# Altium schematic sheet Motor_Drive_V3.02.SchDoc(Motor_Drive_V3.02)
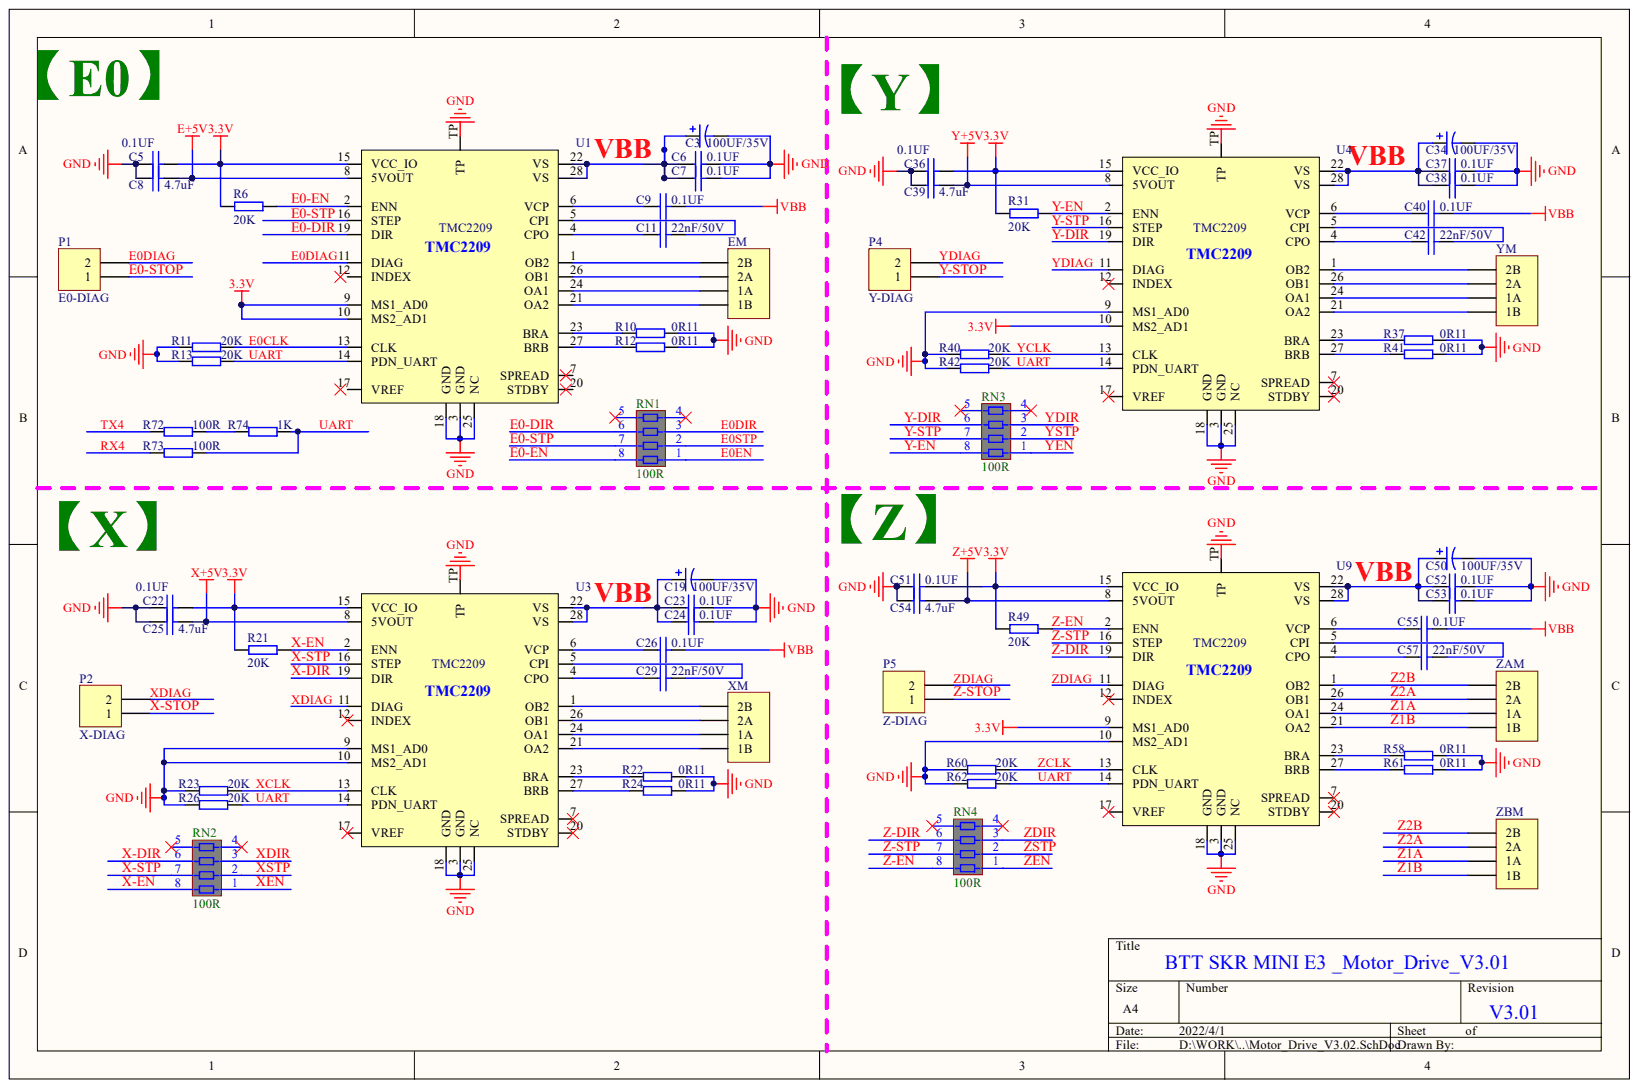
[diagram p0: Motor_Drive_V3.02.SchDoc]

## Parts
C3  value 100UF/35V  [100uF/35V-铝电解电容]  (hidden comment: 35V/100UF)
C5  0.1UF  [0603-C]
C6  0.1UF  [0603-C]
C7  0.1UF  [0603-C]
C8  4.7uF  [0603-C]
C9  0.1UF  [0603-C]
C11  22nF/50V  [0603-C]
C19  value 100UF/35V  [100uF/35V-铝电解电容]  (hidden comment: 35V/100UF)
C22  0.1UF  [0603-C]
C23  0.1UF  [0603-C]
C24  0.1UF  [0603-C]
C25  4.7uF  [0603-C]
C26  0.1UF  [0603-C]
C29  22nF/50V  [0603-C]
C34  value 100UF/35V  [100uF/35V-铝电解电容]  (hidden comment: 35V/100UF)
C36  0.1UF  [0603-C]
C37  0.1UF  [0603-C]
C38  0.1UF  [0603-C]
C39  4.7uF  [0603-C]
C40  0.1UF  [0603-C]
C42  22nF/50V  [0603-C]
C50  value 100UF/35V  [100uF/35V-铝电解电容]  (hidden comment: 35V/100UF)
C51  0.1UF  [0603-C]
C52  0.1UF  [0603-C]
C53  0.1UF  [0603-C]
C54  4.7uF  [0603-C]
C55  0.1UF  [0603-C]
C57  22nF/50V  [0603-C]
EM  XMO  [2.54-4P-直针插座]  (value not drawn)
P1  E0-DIAG  [SIP2-2.54-BLACK]
P2  X-DIAG  [SIP2-2.54-BLACK]
P4  Y-DIAG  [SIP2-2.54-BLACK]
P5  Z-DIAG  [SIP2-2.54-BLACK]
R6  20K  [0603-R]
R10  0R11  [0805R]
R11  20K  [0603-R]
R12  0R11  [0805R]
R13  20K  [0603-R]
R21  20K  [0603-R]
R22  0R11  [0805R]
R23  20K  [0603-R]
R24  0R11  [0805R]
R26  20K  [0603-R]
R31  20K  [0603-R]
R37  0R11  [0805R]
R40  20K  [0603-R]
R41  0R11  [0805R]
R42  20K  [0603-R]
R49  20K  [0603-R]
R58  0R11  [0805R]
R60  20K  [0603-R]
R61  0R11  [0805R]
R62  20K  [0603-R]
R72  100R  [0603-R]
R73  100R  [0603-R]
R74  1K  [0603-R]
RN1  100R  [0603*4]
RN2  100R  [0603*4]
RN3  100R  [0603*4]
RN4  100R  [0603*4]
U1  TMC2209  [QFN28-PAD]
U3  TMC2209  [QFN28-PAD]
U4  TMC2209  [QFN28-PAD]
U9  TMC2209  [QFN28-PAD]
XM  XMO  [2.54-4P-直针插座]  (value not drawn)
YM  XMO  [2.54-4P-直针插座]  (value not drawn)
ZAM  XMO  [2.54-4P-直针插座]  (value not drawn)
ZBM  XMO  [2.54-4P-直针插座]  (value not drawn)

## Nets
3.3V: C5.1 C22.1 C36.1 C51.1 R6.1 R21.1 R31.1 R49.1 U1.9(MS1_AD0) U1.10(MS2_AD1) U1.15(VCC_IO) U3.15(VCC_IO) U4.10(MS2_AD1) U4.15(VCC_IO) U9.9(MS1_AD0) U9.15(VCC_IO)
E+5V: C8.1 U1.8(5VOUT)
GND: C3.2 C5.2 C6.1 C7.1 C8.2 C19.2 C22.2 C23.1 C24.1 C25.2 C34.2 C36.2 C37.1 C38.1 C39.2 C50.2 C51.2 C52.1 C53.1 C54.2 R10.2 R11.1 R12.2 R13.1 R22.2 R23.1 R24.2 R26.1 R37.2 R40.1 R41.2 R42.1 R58.2 R60.1 R61.2 R62.1 U1.3(GND) U1.18(GND) U1.25(NC) U1.TP U3.3(GND) U3.9(MS1_AD0) U3.10(MS2_AD1) U3.18(GND) U3.25(NC) U3.TP U4.3(GND) U4.9(MS1_AD0) U4.18(GND) U4.25(NC) U4.TP U9.3(GND) U9.10(MS2_AD1) U9.18(GND) U9.25(NC) U9.TP
X+5V: C25.1 U3.8(5VOUT)
Y+5V: C39.1 U4.8(5VOUT)
Z+5V: C54.1 U9.8(5VOUT)
E0-DIR: RN1.6 U1.19(DIR)
E0-EN: R6.2 RN1.8 U1.2(ENN)
E0-STOP: P1.1
E0-STP: RN1.7 U1.16(STEP)
E0CLK: R11.2 U1.13(CLK)
E0DIAG: P1.2 U1.11(DIAG)
E0DIR: RN1.3
E0EN: RN1.1
E0STP: RN1.2
RX4: R73.1
TX4: R72.1
UART: R13.2 R26.2 R42.2 R62.2 R73.2 R74.2 U1.14(PDN_UART) U3.14(PDN_UART) U4.14(PDN_UART) U9.14(PDN_UART)
VBB: C3.1 C6.2 C7.2 C9.1 C19.1 C23.2 C24.2 C26.1 C34.1 C37.2 C38.2 C40.1 C50.1 C52.2 C53.2 C55.1 U1.22(VS) U1.28(VS) U3.22(VS) U3.28(VS) U4.22(VS) U4.28(VS) U9.22(VS) U9.28(VS)
X-DIR: RN2.6 U3.19(DIR)
X-EN: R21.2 RN2.8 U3.2(ENN)
X-STOP: P2.1
X-STP: RN2.7 U3.16(STEP)
XCLK: R23.2 U3.13(CLK)
XDIAG: P2.2 U3.11(DIAG)
XDIR: RN2.3
XEN: RN2.1
XSTP: RN2.2
Y-DIR: RN3.6 U4.19(DIR)
Y-EN: R31.2 RN3.8 U4.2(ENN)
Y-STOP: P4.1
Y-STP: RN3.7 U4.16(STEP)
YCLK: R40.2 U4.13(CLK)
YDIAG: P4.2 U4.11(DIAG)
YDIR: RN3.3
YEN: RN3.1
YSTP: RN3.2
Z1A: U9.24(OA1) ZAM.3(1A) ZBM.3(1A)
Z1B: U9.21(OA2) ZAM.4(1B) ZBM.4(1B)
Z2A: U9.26(OB1) ZAM.2(2A) ZBM.2(2A)
Z2B: U9.1(OB2) ZAM.1(2B) ZBM.1(2B)
Z-DIR: RN4.6 U9.19(DIR)
Z-EN: R49.2 RN4.8 U9.2(ENN)
Z-STOP: P5.1
Z-STP: RN4.7 U9.16(STEP)
ZCLK: R60.2 U9.13(CLK)
ZDIAG: P5.2 U9.11(DIAG)
ZDIR: RN4.3
ZEN: RN4.1
ZSTP: RN4.2
NetC9_2: C9.2 U1.6(VCP)
NetC11_1: C11.1 U1.5(CPI)
NetC11_2: C11.2 U1.4(CPO)
NetC26_2: C26.2 U3.6(VCP)
NetC29_1: C29.1 U3.5(CPI)
NetC29_2: C29.2 U3.4(CPO)
NetC40_2: C40.2 U4.6(VCP)
NetC42_1: C42.1 U4.5(CPI)
NetC42_2: C42.2 U4.4(CPO)
NetC55_2: C55.2 U9.6(VCP)
NetC57_1: C57.1 U9.5(CPI)
NetC57_2: C57.2 U9.4(CPO)
NetEM_1: EM.1(2B) U1.1(OB2)
NetEM_2: EM.2(2A) U1.26(OB1)
NetEM_3: EM.3(1A) U1.24(OA1)
NetEM_4: EM.4(1B) U1.21(OA2)
NetR10_1: R10.1 U1.23(BRA)
NetR12_1: R12.1 U1.27(BRB)
NetR22_1: R22.1 U3.23(BRA)
NetR24_1: R24.1 U3.27(BRB)
NetR37_1: R37.1 U4.23(BRA)
NetR41_1: R41.1 U4.27(BRB)
NetR58_1: R58.1 U9.23(BRA)
NetR61_1: R61.1 U9.27(BRB)
NetR72_2: R72.2 R74.1
NetU3_1: U3.1(OB2) XM.1(2B)
NetU3_21: U3.21(OA2) XM.4(1B)
NetU3_24: U3.24(OA1) XM.3(1A)
NetU3_26: U3.26(OB1) XM.2(2A)
NetU4_1: U4.1(OB2) YM.1(2B)
NetU4_21: U4.21(OA2) YM.4(1B)
NetU4_24: U4.24(OA1) YM.3(1A)
NetU4_26: U4.26(OB1) YM.2(2A)

Not connected: RN1 4 5; RN2 4 5; RN3 4 5; RN4 4 5; U1 7(SPREAD) 12(INDEX) 17(VREF) 20(STDBY); U3 7(SPREAD) 12(INDEX) 17(VREF) 20(STDBY); U4 7(SPREAD) 12(INDEX) 17(VREF) 20(STDBY); U9 7(SPREAD) 12(INDEX) 17(VREF) 20(STDBY)

## Library data of the parts
C3 C19 C34 C50 = Cap Pol1: Component Kind: Standard; Description: 35V/100UF; LatestRevisionDate: 17-Jul-2002; LatestRevisionNote: Re-released for DXP Platform.; Library Name: Miscellaneous Devices.IntLib; PackageDescription: Polarized Capacitor (Radial); 2 Leads; PackageReference: RB7.6-15; Published: 8-Jun-2000; Signal Integrity: Cap Pol; Simulation: CAP; Publisher: Altium Limited
C5-C9 C11 C22-C26 C29 C36-C40 C42 C51-C55 C57 = CAP: 电容; Component Kind: Standard; Library Name: 常规.SchLib
EM XM YM ZAM ZBM = Header 4: Header, 4-Pin; Component Kind: Standard; LatestRevisionDate: 17-Jul-2002; LatestRevisionNote: Re-released for DXP Platform.; Library Name: Miscellaneous Connectors.IntLib; Signal Integrity: Connector; Publisher: Altium Limited
P1 P2 P4 P5 = Header 2: Header, 2-Pin; Component Kind: Standard; LatestRevisionDate: 17-Jul-2002; LatestRevisionNote: Re-released for DXP Platform.; Library Name: Miscellaneous Connectors.IntLib; Signal Integrity: Connector; Publisher: Altium Limited
R6 R10-R13 R21-R24 R26 R31 R37 R40-R42 R49 R58 R60-R62 R72-R74 = RES: 电阻; Component Kind: Standard; Library Name: 常规.SchLib
RN1-RN4 = RES-4: Component Kind: Standard; Library Name: liroqi.SchLib
U1 U3 U4 U9 = TMC2209: 驱动芯片; Component Kind: Standard; Library Name: 芯片.SchLib
